# Altium schematic sheet lps_regulator_rev_11.SchDoc(lps_regulator_rev_11)
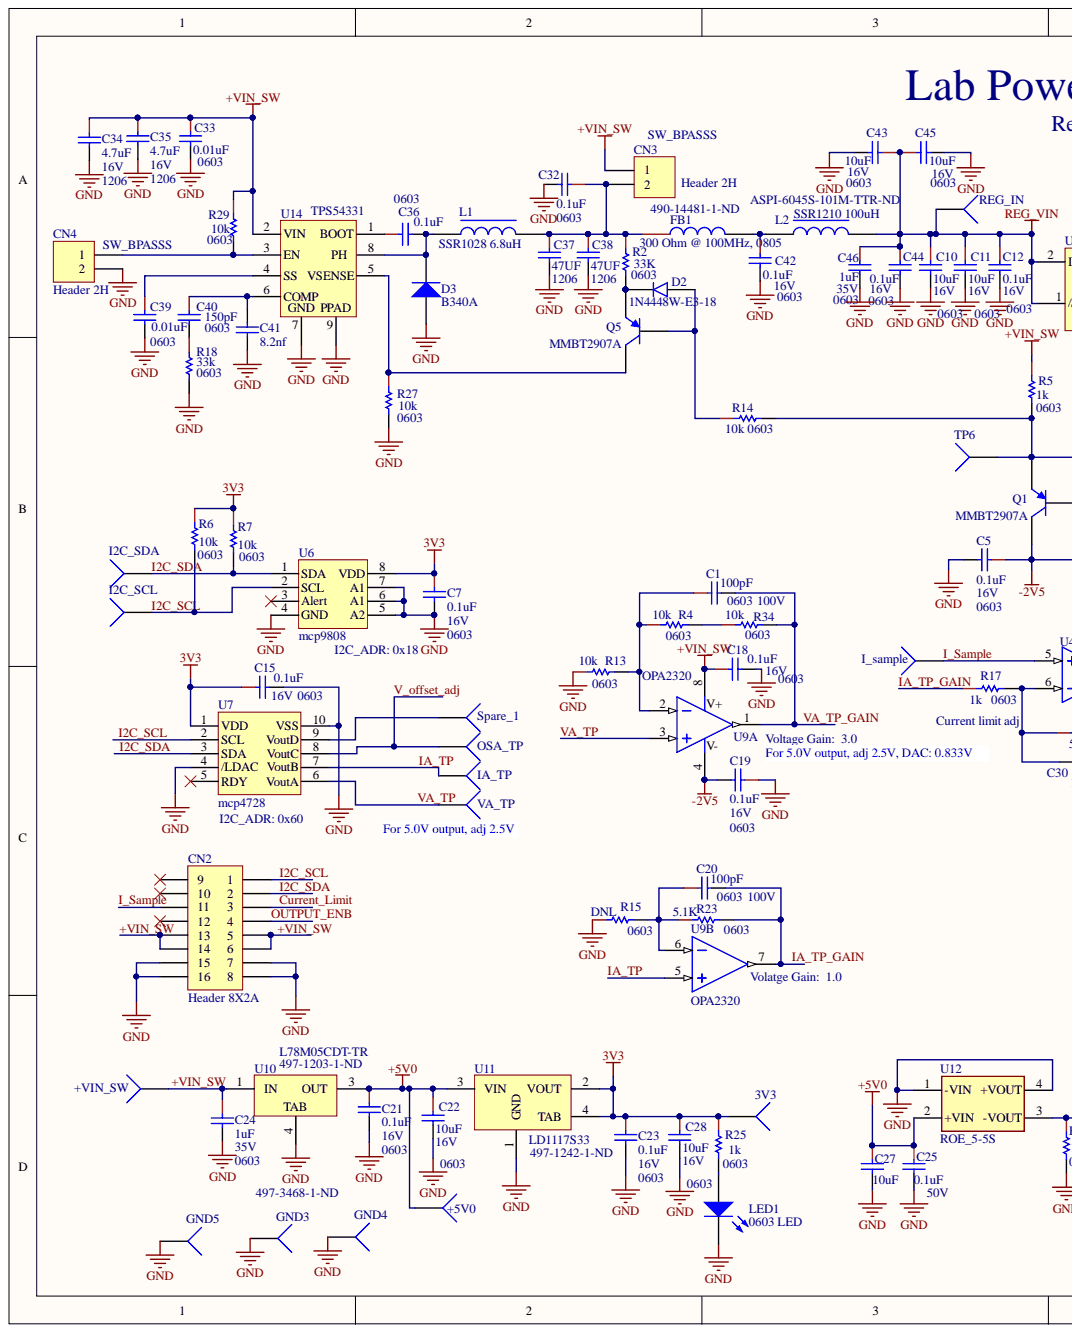
[diagram p0: lps_regulator_rev_11.SchDoc, left]
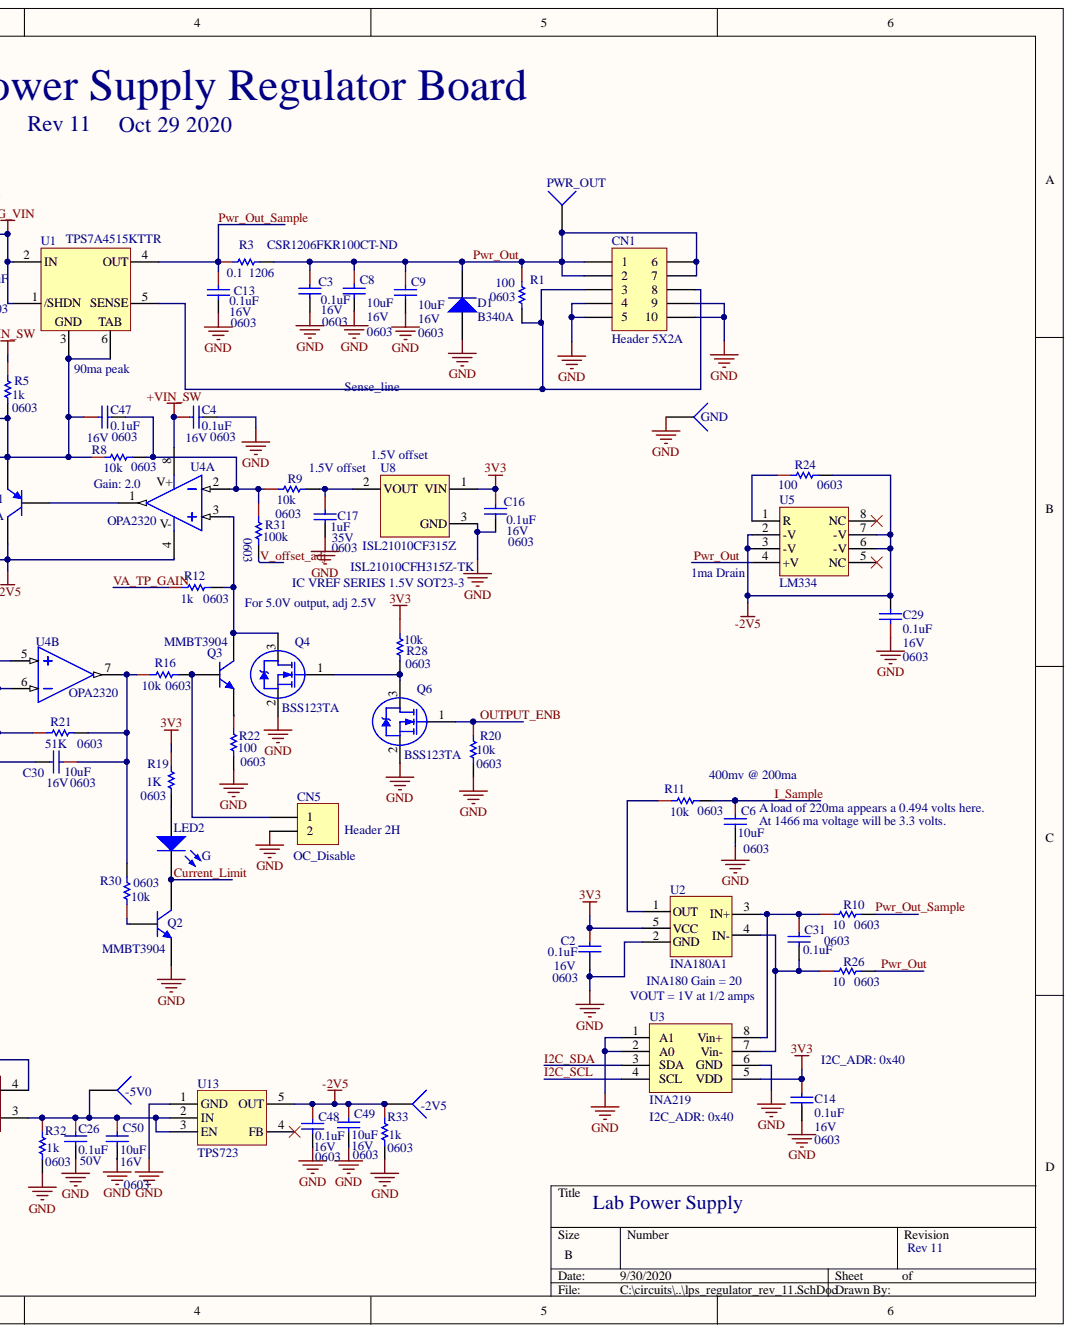
[diagram p1: lps_regulator_rev_11.SchDoc, right]

## Parts
3V3  TestPoint_BLK  [TestPoint-TH 42 mil]  (value not drawn)
+5V0  TestPoint_BLK  [TestPoint-TH 42 mil]  (value not drawn)
+VIN_SW  TestPoint_BLK  [TestPoint-TH 42 mil]  (value not drawn)
-2V5  TestPoint_BLK  [TestPoint-TH 42 mil]  (value not drawn)
-5V0  TestPoint_BLK  [TestPoint-TH 42 mil]  (value not drawn)
C1  100pF  Package (Imperial): 0603  [CAPC0603(1608)_N]
C2  0.1uF  Voltage (Rated): 16V  Package (Imperial): 0603  [CAPC0603(1608)_M]
C3  0.1uF  Voltage (Rated): 16V  Package (Imperial): 0603  [CAPC0603(1608)_N]
C4  0.1uF  Voltage (Rated): 16V  Package (Imperial): 0603  [CAPC0603(1608)_N]
C5  0.1uF  Voltage (Rated): 16V  Package (Imperial): 0603  [CAPC0603(1608)_N]
C6  10uF  Package (Imperial): 0603  [CAPC0603(1608)_N]
C7  0.1uF  Voltage (Rated): 16V  Package (Imperial): 0603  [CAPC0603(1608)_M]
C8  10uF  Voltage (Rated): 16V  Package (Imperial): 0603  [CAPC0603(1608)_N]
C9  10uF  Voltage (Rated): 16V  Package (Imperial): 0603  [CAPC0603(1608)_N]
C10  10uF  Voltage (Rated): 16V  Package (Imperial): 0603  [CAPC0603(1608)_N]
C11  10uF  Voltage (Rated): 16V  Package (Imperial): 0603  [CAPC0603(1608)_N]
C12  0.1uF  Voltage (Rated): 16V  Package (Imperial): 0603  [CAPC0603(1608)_N]
C13  0.1uF  Voltage (Rated): 16V  Package (Imperial): 0603  [CAPC0603(1608)_M]
C14  0.1uF  Voltage (Rated): 16V  Package (Imperial): 0603  [CAPC0603(1608)_N]
C15  0.1uF  Voltage (Rated): 16V  Package (Imperial): 0603  [CAPC0603(1608)_N]
C16  0.1uF  Voltage (Rated): 16V  Package (Imperial): 0603  [CAPC0603(1608)_N]
C17  1uF  Voltage (Rated): 35V  Package (Imperial): 0603  [CAPC0603(1608)_M]
C18  0.1uF  Voltage (Rated): 16V  Package (Imperial): 0603  [CAPC0603(1608)_M]
C19  0.1uF  Voltage (Rated): 16V  Package (Imperial): 0603  [CAPC0603(1608)_M]
C20  100pF  Voltage (Rated): 100V  Package (Imperial): 0603  [CAPC0603(1608)_N]
C21  0.1uF  Voltage (Rated): 16V  Package (Imperial): 0603  [CAPC0603(1608)_M]
C22  10uF  Voltage (Rated): 16V  Package (Imperial): 0603  [CAPC0603(1608)_N]
C23  0.1uF  Voltage (Rated): 16V  Package (Imperial): 0603  [CAPC0603(1608)_M]
C24  1uF  Voltage (Rated): 35V  Package (Imperial): 0603  [CAPC0603(1608)_M]
C25  0.1uF  Voltage - Rated: 50V  [CAPC0805(2012)_N]
C26  0.1uF  Voltage - Rated: 50V  [CAPC0805(2012)_N]
C27  10uF  [CAPC0805(2012)_M]
C28  10uF  Voltage (Rated): 16V  Package (Imperial): 0603  [CAPC0603(1608)_N]
C29  0.1uF  Voltage (Rated): 16V  Package (Imperial): 0603  [CAPC0603(1608)_M]
C30  10uF  Voltage (Rated): 16V  Package (Imperial): 0603  [CAPC0603(1608)_M]
C31  0.1uF  Package (Imperial): 0603  [CAPC0603(1608)_N]
C32  0.1uF  [CAPC0603(1608)_N]
C33  0.01uF  Package (Imperial): 0603  [CAPC0603(1608)_N]
C34  4.7uF  Voltage (Rated): 16V  Package (Imperial): 1206  [CAPC1206(3216)_N]
C35  4.7uF  Voltage (Rated): 16V  Package (Imperial): 1206  [CAPC1206(3216)_N]
C36  0.1uF  Package (Imperial): 0603  [CAPC0603(1608)_N]
C37  47UF  Package (Imperial): 1206  [CAPC1206(3216)_L]
C38  47UF  Package (Imperial): 1206  [CAPC1206(3216)_L]
C39  0.01uF  Package (Imperial): 0603  [CAPC0603(1608)_N]
C40  150pF  Package (Imperial): 0603  [CAPC0603(1608)_M]
C41  8.2nf  Case Code (Imperial): 0603  [CAPC1608X87X35ML15T15]
C42  0.1uF  Voltage (Rated): 16V  Package (Imperial): 0603  [CAPC0603(1608)_N]
C43  10uF  Voltage (Rated): 16V  Package (Imperial): 0603  [CAPC0603(1608)_N]
C44  0.1uF  Voltage (Rated): 16V  Package (Imperial): 0603  [CAPC0603(1608)_N]
C45  10uF  Voltage (Rated): 16V  [CAPC0603(1608)_N]
C46  1uF  Voltage (Rated): 35V  Package (Imperial): 0603  [CAPC0603(1608)_M]
C47  0.1uF  Voltage (Rated): 16V  Package (Imperial): 0603  [CAPC0603(1608)_N]
C48  0.1uF  Voltage (Rated): 16V  Package (Imperial): 0603  [CAPC0603(1608)_M]
C49  10uF  Voltage (Rated): 16V  Package (Imperial): 0603  [CAPC0603(1608)_N]
C50  10uF  Voltage (Rated): 16V  Package (Imperial): 0603  [CAPC0603(1608)_N]
CN1  Header 5X2A  [HDR2X5_CEN]
CN2  Header 8X2A  [HDR2X8_CEN]
CN3  Header 2H  [HDR1X2H]
CN4  Header 2H  [HDR1X2H]
CN5  Header 2H  [HDR1X2H]
D1  B340A  [DO-214AC]
D2  1N4448W-E3-18  [SOD123]
D3  B340A  [DO-214AC]
FB1  300 Ohm @ 100MHz, 0805  [RESC0805(2012)_N]
GND  TestPoint_BLK  [TestPoint-TH 42 mil]  (value not drawn)
GND3  TestPoint_BLK  [TestPoint-TH 42 mil]  (value not drawn)
GND4  TestPoint_BLK  [TestPoint-TH 42 mil]  (value not drawn)
GND5  TestPoint_BLK  [TestPoint-TH 42 mil]  (value not drawn)
I2C_SCL  TestPoint_BLK  [TestPoint-TH 42 mil]  (value not drawn)
I2C_SDA  TestPoint_BLK  [TestPoint-TH 42 mil]  (value not drawn)
I_sample  TestPoint_BLK  [TestPoint-TH 42 mil]  (value not drawn)
IA_TP  TestPoint_BLK  [TestPoint-TH 42 mil]  (value not drawn)
L1  SSR1028 6.8uH  [SRU1028]
L2  SSR1210 100uH  [SRR1210]
LED1  0603 LED  [SM-LED-0603]
LED2  G  [SM-LED-0603]
OSA_TP  TestPoint_BLK  [TestPoint-TH 42 mil]  (value not drawn)
PWR_OUT  TestPoint_BLK  [TestPoint-TH 42 mil]  (value not drawn)
Q1  MMBT2907A  [SOT23]
Q2  MMBT3904  [SOT23]
Q3  MMBT3904  [SOT23]
Q4  BSS123TA  [SOT23-3L]
Q5  MMBT2907A  [SOT23]
Q6  BSS123TA  [SOT23-3L]
R1  100  Package (Imperial): 0603  [RESC0603(1608)_N]
R2  Resistance: 33K  Package (Imperial): 0603  [RESC0603(1608)_M]  (hidden comment: 10K)
R3  Resistance: 0.1  Package (Metric): 1206  [RESC1206(3216)_N]  (hidden comment: 0.33)
R4  10k  Package (Imperial): 0603  [RESC0603(1608)_L]
R5  Resistance: 1k  Package (Imperial): 0603  [RESC0603(1608)_M]  (hidden comment: 10K)
R6  Package (Imperial): 0603  [RESC0603(1608)_M]  (hidden comment: 10K)
R7  Package (Imperial): 0603  [RESC0603(1608)_M]  (hidden comment: 10K)
R8  Package (Imperial): 0603  [RESC0603(1608)_M]  (hidden comment: 10K)
R9  10K  [RESC0603(1608)_M]
R10  Package (Imperial): 0603  [RESC0603(1608)_M]  (hidden comment: 10K)
R11  Package (Imperial): 0603  [RESC0603(1608)_M]  (hidden comment: 10K)
R12  Resistance: 1k  Package (Imperial): 0603  [RESC0603(1608)_M]  (hidden comment: 1.0K)
R13  10k  Package (Imperial): 0603  [RESC0603(1608)_L]
R14  Package (Imperial): 0603  [RESC0603(1608)_M]  (hidden comment: 10K)
R15  Resistance: DNL  Package (Imperial): 0603  [RESC0603(1608)_M]  (hidden comment: 1.0K)
R16  Resistance: 10k  Package (Imperial): 0603  [RESC0603(1608)_M]  (hidden comment: 1.0K)
R17  Resistance: 1k  Package (Imperial): 0603  [RESC0603(1608)_M]  (hidden comment: 1.0K)
R18  Package (Imperial): 0603  [RESC0603(1608)_N]  (hidden comment: 33K)
R19  Resistance: 1K  Package (Imperial): 0603  [RESC0603(1608)_M]  (hidden comment: 1.0K)
R20  Package (Imperial): 0603  [RESC0603(1608)_M]  (hidden comment: 10K)
R21  Resistance: 51K  Package (Imperial): 0603  [RESC0603(1608)_M]  (hidden comment: 100K)
R22  Package (Imperial): 0603  [RESC0603(1608)_M]  (hidden comment: 1.0K)
R23  100  Package (Imperial): 0603  [RESC0603(1608)_N]
R24  100  Package (Imperial): 0603  [RESC0603(1608)_N]
R25  Resistance: 1k  Package (Imperial): 0603  [RESC0603(1608)_M]  (hidden comment: 1.0K)
R26  Package (Imperial): 0603  [RESC0603(1608)_M]  (hidden comment: 10K)
R27  Package (Imperial): 0603  [RESC0603(1608)_M]  (hidden comment: 10K)
R28  Package (Imperial): 0603  [RESC0603(1608)_M]  (hidden comment: 10K)
R29  Package (Imperial): 0603  [RESC0603(1608)_M]  (hidden comment: 10K)
R30  Resistance: 10k  Package (Imperial): 0603  [RESC0603(1608)_M]  (hidden comment: 1.0K)
R31  Resistance: 100k  Package (Imperial): 0603  [RESC0603(1608)_M]  (hidden comment: 1.0K)
R32  Resistance: 1k  Package (Imperial): 0603  [RESC0603(1608)_M]  (hidden comment: 1.0K)
R33  Resistance: 1k  Package (Imperial): 0603  [RESC0603(1608)_M]  (hidden comment: 1.0K)
R34  10k  Package (Imperial): 0603  [RESC0603(1608)_L]
REG_IN  TestPoint_BLK  [TestPoint-TH 42 mil]  (value not drawn)
Spare_1  TestPoint_BLK  [TestPoint-TH 42 mil]  (value not drawn)
TP6  TestPoint_BLK  [TestPoint-TH 42 mil]  (value not drawn)
U1  TPS7A4515KTTR  [TPS7A4515KTTR]
U2  INA180A1  [SOT-23-5_MC]
U3  INA219  [INA219]
U4  OPA2320  [SOIC-8_NoPad]  (parts A,B)
U5  LM334  [LM334]
U6  mcp9808  [8MSOP]
U7  mcp4728  [MCP4728]
U8  ISL21010CF315Z  [SOT23]
U9  OPA2320  [SOIC-8_NoPad]  (parts A,B)
U10  (no value)  [TO-252_N]
U11  LD1117S33  [DSO-G3]
U12  ROE_5-5S  [ROE_5-55]
U13  TPS723  [SOt-23-5]
U14  TPS54331  [TPS54331]
VA_TP  TestPoint_BLK  [TestPoint-TH 42 mil]  (value not drawn)

## Nets
3V3: 3V3.1 C2.1 C7.1 C14.1 C15.1 C16.1 C23.1 C28.1 R6.1 R7.1 R19.1 R25.1 R28.1 U2.5(VCC) U3.5(VDD) U6.8(VDD) U7.1(VDD) U8.1 U11.2(VOUT) U11.4(TAB)
+5V0: +5V0.1 C21.1 C22.1 C25.1 C27.1 U10.3 U11.3(VIN) U12.2(+VIN)
-2V5: -2V5.1 C5.1 C19.2 C29.2 C48.1 C49.1 Q1.3 R24.2 R33.1 U4.4 U5.2(-V) U5.3(-V) U5.6(-V) U5.7(-V) U9.4 U13.5(OUT)
GND: C2.2 C3.2 C4.2 C5.2 C6.2 C7.2 C8.2 C9.2 C10.2 C11.2 C12.2 C13.2 C14.2 C15.2 C16.2 C17.2 C18.2 C19.1 C21.2 C22.2 C23.2 C24.2 C25.2 C26.2 C27.2 C28.2 C29.1 C32.2 C33.2 C34.2 C35.2 C37.2 C38.2 C39.2 C41.1 C42.2 C43.2 C44.2 C45.2 C46.2 C48.2 C49.2 C50.2 CN1.4 CN1.5 CN1.9 CN1.10 CN2.7 CN2.8 CN2.15 CN2.16 CN4.2 CN5.2 D1.1 D3.1 GND.1 GND3.1 GND4.1 GND5.1 LED1.2 Q2.2 Q4.2 Q6.2 R13.1 R15.1 R18.2 R20.2 R22.2 R27.2 R32.2 R33.2 U2.2(GND) U3.1(A1) U3.2(A0) U3.6(GND) U6.4(GND) U6.5(A2) U6.6(A1) U6.7(A1) U7.4(/LDAC) U7.10(VSS) U8.3 U10.4 U11.1(GND) U12.1(-VIN) U12.4(+VOUT) U13.1(GND) U14.7 U14.9
REG_VIN: C10.1 C11.1 C12.1 C43.1 C44.1 C45.1 C46.1 L2.2 REG_IN.1 U1.1(/SHDN) U1.2(IN)
+VIN_SW: +VIN_SW.1 C4.1 C18.1 C24.1 C33.1 C34.1 C35.1 CN2.5 CN2.6 CN2.13 CN2.14 CN3.1 R5.1 R29.1 U4.8 U9.8 U10.1 U14.2(VIN)
Current_Limit: CN2.3 LED2.2 Q2.3
I2C_SCL: CN2.1 I2C_SCL.1 R6.2 U3.4(SCL) U6.2(SCL) U7.2(SCL)
I2C_SDA: CN2.2 I2C_SDA.1 R7.2 U3.3(SDA) U6.1(SDA) U7.3(SDA)
I_Sample: C6.1 CN2.11 I_sample.1 R11.2 U4.5
IA_TP: IA_TP.1 U7.7(VoutB) U9.5
IA_TP_GAIN: C20.2 R17.1 R23.2 U9.7
OUTPUT_ENB: CN2.4 Q6.1 R20.1
Pwr_Out: C3.1 C8.1 C9.1 CN1.1 CN1.2 CN1.6 CN1.7 D1.2 PWR_OUT.1 R1.1 R3.2 R26.2 U5.4(+V)
Pwr_Out_Sample: C13.1 R3.1 R10.2 U1.4(OUT)
V_offset_adj: OSA_TP.1 R31.2 U7.8(VoutC)
VA_TP: U7.6(VoutA) U9.3 VA_TP.1
VA_TP_GAIN: C1.2 R12.1 R34.2 U9.1
Net-5V0_1: -5V0.1 C26.1 C50.1 R32.1 U12.3(-VOUT) U13.2(IN) U13.3(EN)
NetC1_1: C1.1 R4.1 R13.2 U9.2
NetC17_1: C17.1 R9.2 U8.2
NetC20_1: C20.1 R15.2 R23.1 U9.6
NetC30_1: C30.1 R16.1 R21.2 R30.2 U4.7
NetC30_2: C30.2 R17.2 R21.1 U4.6
NetC31_1: C31.1 R10.1 U2.3(IN+) U3.8(Vin+)
NetC31_2: C31.2 R26.1 U2.4(IN-) U3.7(Vin-)
NetC32_1: C32.1 C37.1 C38.1 CN3.2 FB1.1 L1.2 R2.1
NetC36_1: C36.1 U14.1(BOOT)
NetC36_2: C36.2 D3.2 L1.1 U14.8(PH)
NetC39_1: C39.1 U14.4(SS)
NetC40_1: C40.1 C41.2 U14.6(COMP)
NetC40_2: C40.2 R18.1
NetC42_1: C42.1 FB1.2 L2.1
NetC47_1: C47.1 Q1.2 R5.2 R8.1 R14.2 TP6.1 U1.3 U1.6
NetC47_2: C47.2 R8.2 R9.1 R31.1 U4.2
NetCN1_3: CN1.3 CN1.8 R1.2 U1.5(SENSE)
NetCN4_1: CN4.1 R29.2 U14.3(EN)
NetCN5_1: CN5.1 Q3.1 R16.2
NetD2_1: D2.1 Q5.1 R14.1
NetD2_2: D2.2 Q5.2 R2.2
NetLED1_1: LED1.1 R25.2
NetLED2_1: LED2.1 R19.2
NetQ1_1: Q1.1 U4.1
NetQ2_1: Q2.1 R30.1
NetQ3_2: Q3.2 R22.1
NetQ3_3: Q3.3 Q4.3 R12.2 U4.3
NetQ4_1: Q4.1 Q6.3 R28.2
NetQ5_3: Q5.3 R27.1 U14.5(VSENSE)
NetR4_2: R4.2 R34.1
NetR11_1: R11.1 U2.1(OUT)
NetR24_1: R24.1 U5.1(R)
NetSpare_1_1: Spare_1.1 U7.9(VoutD)

Not connected: CN2 9 10 12; U5 5(NC) 8(NC); U6 3(Alert); U7 5(RDY); U13 4(FB)

## Library data of the parts
3V3 +5V0 +VIN_SW -2V5 -5V0 GND GND3-GND5 I2C_SCL I2C_SDA I_sample IA_TP OSA_TP PWR_OUT REG_IN Spare_1 TP6 VA_TP = CMP-005-0210-01: Test Point - Black; Manufacturer Part Number: 5001; Manufacturer: Keystone Electronics; Type: PC Test Point, Miniature; Terminal: 0.010" x 0.020" (0.25mm x 0.51mm) Phosphor Bronze Wire, Silver Plated; Stock 1: 335679; RoHS: RoHS Compliant; Pricing 1: 1=0.37, 10=0.316, 50=0.2298, 100=0.2209, 250=0.19832, 500=0.18932, 1000=0.1616, 2500=0.14424, 5000=0.13523 (USD); Pin Count: 1; Packaging: Bulk; Mounting Type: 0.040" (1.02mm) Hole Diameter; Material: Base, Nylon 46, UL 94V-0; Designator: TestPoint_BLK; Component Name: TestPoint_BLK; ComponentLink1URL: http://www.keyelco.com/product-pdf.cfm?p=1310; ComponentLink1Description: http://www.keyelco.com/product-pdf.cfm?p=1310; Component Kind: Standard; Color: Black; Library Name: OLogic Nexus Vault
C1 = CMP-007-0074-08: CAP CER 100PF 50V 5% NP0 0603; Voltage (Rated): 100V; Temperature Coefficient: C0G, NP0; Package (Metric): 1608; Dielectric Material: Ceramic; Tolerance: 5%; Mounting Type: SMD; Component Kind: Standard; Capacitance: 100pF; Library Name: OLogic Nexus Vault
C2-C5 C7 C12-C16 C18 C19 C21 C23 C29-C31 C36 C42 C44 C47 C48 = CMP-007-0042-08: CAP CER 0.1UF 16V 10% X7R 0603; Package (Metric): 1608; Dielectric Material: Ceramic; Capacitance: 100nF; Tolerance: 10%; Temperature Coefficient: X7R; Mounting Type: SMD; Component Kind: Standard; Library Name: OLogic Nexus Vault
  C31 C36: Voltage (Rated): 16V
C6 C8-C11 C22 C28 C43 C49 C50 = CMP-001-0603-12: CAP CER 10UF 16V X5R 0603; Tolerance: 10%; Temperature (Min): -55°C; Temperature (Max): 85°C; Package (Metric): 1005; Dielectric Material: Ceramic; Capacitance: 10µF; Width: 800µm; Temperature Coefficient: X5R; Mounting Type: SMD; Height: 800µm; Component Kind: Standard; Library Name: OLogic Nexus Vault
  C6: Voltage (Rated): 16V
C17 C24 C40 C46 = CMP-007-0109-08: CAP CER 1UF 35V 10% X5R 0603; Temperature (Min): -55°C; Temperature (Max): 85°C; Package (Metric): 1608; Dielectric Material: Ceramic; Capacitance: 1µF; Width: 800µm; Tolerance: 10%; Temperature Coefficient: X5R; Mounting Type: SMD; Length: 1.6mm; Height: 900µm; Component Kind: Standard; Library Name: OLogic Nexus Vault
  C40: Voltage (Rated): 35V
C20 = CMP-007-0074-08: CAP CER 100PF 50V 5% NP0 0603; Temperature Coefficient: C0G, NP0; Package (Metric): 1608; Dielectric Material: Ceramic; Tolerance: 5%; Mounting Type: SMD; Component Kind: Standard; Capacitance: 100pF; Library Name: OLogic Nexus Vault
C25 C26 = CAP CER 0.1UF 50V 10% X7R 0805: 0.1uF 0805 50V; Manufacturer Part Number: C0805C104K5RACTU; Manufacturer: Kemet; Tolerance: ±10%; Temperature Coefficient: X7R; Capacitance: 0.1µF; Thickness (Max): 0.035" (0.88mm); Supplier Part Number 1: 399-1170-1-ND; Supplier 1: Digi-Key; Stock 1: 2639857; Size / Dimension: 0.079" L x 0.049" W (2.00mm x 1.25mm); RoHS: RoHS Compliant; Ratings: -; Pricing 1: 1=0.1, 10=0.049, 25=0.0348, 50=0.0266, 100=0.0224, 250=0.01836, 1000=0.012 (USD); Packaging: Cut Tape (CT); Package / Case: 0805 (2012 Metric); Operating Temperature: -55°C ~ 125°C; Mounting Type: Surface Mount, MLCC; Library Name: OLogic-Passive.IntLib; Lead Style: -; Lead Spacing: -; Height - Seated (Max): -; Features: -; ComponentLink1URL: http://www.kemet.com/datasheets&C0805C104K5RACTU; ComponentLink1Description: http://www.kemet.com/datasheets&C0805C104K5RACTU; Component Kind: Standard; Category: Capacitors; Applications: General Purpose
C27 = CAP CER 10UF 16V 10% X5R 0805: 10UF 0805 16V; Manufacturer Part Number: GRM21BR61C106KE15L; Manufacturer: Murata Electronics North America; Tolerance: ±10%; Temperature Coefficient: X5R; Capacitance: 10µF; Voltage - Rated: 16V; Thickness (Max): 0.053" (1.35mm); Supplier Part Number 1: 490-3886-1-ND; Supplier 1: Digi-Key; Stock 1: 1725870; Size / Dimension: 0.079" L x 0.049" W (2.00mm x 1.25mm); RoHS: RoHS Compliant; Ratings: -; Pricing 1: 1=0.25, 10=0.171, 50=0.1038, 100=0.0872, 250=0.07056, 500=0.06226, 1000=0.05291 (USD); Packaging: Cut Tape (CT); Package / Case: 0805 (2012 Metric); Operating Temperature: -55°C ~ 85°C; Mounting Type: Surface Mount, MLCC; Library Name: OLogic-Passive.IntLib; Lead Style: -; Lead Spacing: -; Height - Seated (Max): -; Features: -; ComponentLink1URL: http://www.murata.com/products/catalog/pdf/c02e.pdf; ComponentLink1Description: http://www.murata.com/products/catalog/pdf/c02e.pdf; Component Kind: Standard; Category: Capacitors; Applications: General Purpose
C32 = CMP-007-0042-08: CAP CER 0.1UF 16V 10% X7R 0603; Package (Metric): 1608; Package (Imperial): 0603; Dielectric Material: Ceramic; Capacitance: 100nF; Voltage (Rated): 16V; Tolerance: 10%; Temperature Coefficient: X7R; Mounting Type: SMD; Component Kind: Standard; Library Name: OLogic Nexus Vault
C33 = CMP-007-0028-08: CAP CER 0.01uF 50V 10% X7R 0603; Temperature (Min): -55°C; Temperature (Max): 125°C; Package (Metric): 1608; Dielectric Material: Ceramic; Capacitance: 10nF; Width: 800µm; Voltage (Rated): 50V; Tolerance: 10%; Temperature Coefficient: X7R; Mounting Type: SMD; Length: 1.6mm; Height: 900µm; Component Kind: Standard; Library Name: OLogic Nexus Vault
C34 C35 = CMP-007-0147-08: CAP CER 4.7UF 50V 10% X7R 1206; Package (Metric): 3216; Dielectric Material: Ceramic; Capacitance: 4.7µF; Tolerance: 10%; Temperature Coefficient: X7R; Mounting Type: SMD; Component Kind: Standard; Library Name: OLogic Nexus Vault
C37 C38 = CMP-001-0623-11: CAP CER 47UF 25V 1206; Voltage (Rated): 100V; Tolerance: 20%; Temperature Coefficient: X5R; Temperature (Min): -55°C; Temperature (Max): 85°C; Package (Metric): 3216; Dielectric Material: Ceramic; Capacitance: 47µF; Mounting Type: SMD; Length: 3.2mm; Height: 1.6mm; Component Kind: Standard; Library Name: OLogic Nexus Vault
C39 = CMP-007-0128-08: CAP CER 22PF 50V 5% NP0 0603; Temperature Coefficient: C0G, NP0; Package (Metric): 1608; Dielectric Material: Ceramic; Voltage (Rated): 50V; Tolerance: 5%; Mounting Type: SMD; Component Kind: Standard; Capacitance: 22pF; Library Name: OLogic Nexus Vault
C41 = CMP-001-0751-01: CAP CER 820PF 50V X7R 0603; Tolerance: 10%; Dissipation Factor: 2.5%; Dielectric Material: Ceramic; Width: 0.8mm; Voltage Rating (DC): 50V; Voltage Rating: 50V; Voltage: 50V; Thickness: 0.034inch; Termination: SMD/SMT; REACH SVHC: No SVHC; Radiation Hardening: No; Packaging: Tape and Reel; Package Quantity: 1; Mount: PCB, Surface Mount; Min Operating Temperature: -55°C; Max Operating Temperature: 125°C; Length: 1.6mm; Lead Pitch: 0.7mm; Height: 0.8mm; Dielectric: X7R; Depth: 0.8mm; Contact Plating: Matte, Tin; Component Kind: Standard; Case Code (Metric): 1608; Case/Package: 0603; Capacitance: 820pF; Library Name: OLogic Nexus Vault
C45 = CMP-001-0603-12: CAP CER 10UF 16V X5R 0603; Tolerance: 10%; Temperature (Min): -55°C; Temperature (Max): 85°C; Package (Metric): 1005; Package (Imperial): 0603; Dielectric Material: Ceramic; Capacitance: 10µF; Width: 800µm; Temperature Coefficient: X5R; Mounting Type: SMD; Height: 800µm; Component Kind: Standard; Library Name: OLogic Nexus Vault
CN1 = CMP-001-0310-01: Header, 5-Pin, Dual row; Signal Integrity: Connector; Pin Count: 10; LatestRevisionNote: Re-released for DXP Platform.; LatestRevisionDate: 17-Jul-2002; Designator: Header 5X2A; Component Name: Header 5X2A; Component Kind: Standard; Publisher: Altium Limited; Library Name: OLogic Nexus Vault
CN2 = CMP-001-0325-01: Header, 8-Pin, Dual row; Signal Integrity: Connector; Pin Count: 16; LatestRevisionNote: Re-released for DXP Platform.; LatestRevisionDate: 17-Jul-2002; Designator: Header 8X2A; Component Name: Header 8X2A; Component Kind: Standard; Publisher: Altium Limited; Library Name: OLogic Nexus Vault
CN3-CN5 = CMP-001-0287-01: Header, 2-Pin, Right Angle; Manufacturer Part Number: M20-9960245; Manufacturer: Harwin Inc.; Style: Board to Board or Cable; Shrouding: Unshrouded; Pitch - Mating: 0.100" (2.54mm); Number of Positions Loaded: All; Number of Positions: 2; Material Flammability Rating: UL94 V-0; Mated Stacking Heights: 11.72mm, 12.62mm, 12.72mm, 13.62mm, 18.22mm, 19.12mm; Current Rating: 3A per Contact; Contact Length - Post: 0.118" (3.00mm); Contact Length - Mating: 0.240" (6.10mm); Connector Type: Header, Cuttable; Voltage Rating: -; Termination: Solder; Stock 1: 17504; Signal Integrity: Connector; Row Spacing - Mating: -; RoHS: RoHS Compliant; Pricing 1: 1=0.15, 10=0.141, 100=0.087, 500=0.072, 1000=0.063, 5000=0.054, 10000=0.0525 (USD); Pin Count: 2; Part Status: Active; Packaging: Bulk; Overall Contact Length: -; Operating Temperature: -40°C ~ 105°C; Number of Rows: 1; Mounting Type: Through Hole, Right Angle; LatestRevisionNote: Re-released for DXP Platform.; LatestRevisionDate: 17-Jul-2002; Insulation Material: Polyamide (PA6T), Nylon 6T; Insulation Height: 0.100" (2.54mm); Insulation Color: Black; Ingress Protection: -; Features: -; Fastening Type: -; Designator: Header 2H; Contact Type: Male Pin; Contact Shape: Square; Contact Material: Brass; Contact Finish Thickness - Post: -; Contact Finish Thickness - Mating: -; Contact Finish - Post: -; Contact Finish - Mating: Gold; Component Name: Header 2H; ComponentLink1URL: https://cdn.harwin.com/pdfs/M20-996.pdf; ComponentLink1Description: https://cdn.harwin.com/pdfs/M20-996.pdf; Component Kind: Standard; Applications: -; Publisher: Altium Limited; Library Name: OLogic Nexus Vault
D1 D3 = CMP-001-0130-02: DIODE SCHOTTKY 60V 3A; Manufacturer Part Number: SK36A-LTP; Manufacturer: Micro Commercial Co; Voltage - Forward (Vf) (Max) @ If: 750mV @ 3A; Speed: Fast Recovery =< 500ns, > 200mA (Io); Current - Reverse Leakage @ Vr: 500µA @ 60V; Capacitance @ Vr, F: 250pF @ 4V, 1MHz; Supplier Device Package: DO-214AC (SMA); Stock 1: 26020; RoHS: RoHS Compliant; Pricing 1: 1=0.6, 10=0.42, 25=0.3448, 100=0.276, 250=0.20072, 500=0.1631, 1000=0.12545, 2500=0.11291 (USD); Pin Count: 2; Part Status: Active; Packaging: Cut Tape (CT); Package / Case: DO-214AC, SMA; Operating Temperature - Junction: -50°C ~ 125°C; Mounting Type: Surface Mount; Diode Type: Schottky; Designator: SK36A-LTP; Current - Average Rectified (Io): 3A; Component Name: SK36A-LTP; ComponentLink1URL: http://www.mccsemi.com/up_pdf/SK32A-LT~SK310A-LT(DO-214AC).PDF; ComponentLink1Description: http://www.mccsemi.com/up_pdf/SK32A-LT~SK310A-LT(DO-214AC).PDF; Component Kind: Standard; Library Name: OLogic Nexus Vault
D2 = CMP-001-0983-02: Diodes - General Purpose, Power, Switching 100 Volt 500mA 4ns; Max Reverse Voltage (DC): 75V; Max Reverse Leakage Current: 5uA; Max Repetitive Reverse Voltage (Vrrm): 100V; Max Power Dissipation: 500mW; Forward Current: 150mA; Element Configuration: Single; Weight: 0.000363oz; Reverse Recovery Time: 4ns; Recovery Time: 4ns; Peak Non-Repetitive Surge Current: 500mA; Packaging: Tape and Reel; Package Quantity: 10000; Mount: Surface Mount; Min Operating Temperature: -55°C; Max Surge Current: 500mA; Max Operating Temperature: 150°C; Contact Plating: Tin; Component Kind: Standard; Average Rectified Current: 150mA; Library Name: OLogic Nexus Vault
FB1 = CMP-007-0197-02: 600 Ohms @ 100MHz 1 Signal Line Ferrite Bead 0805 (2012 Metric) 2A 150mOhm; Manufacturer Part Number: 742792040; Manufacturer: Wurth Electronics Inc; Filter Type: Differential Mode - Single; DC Resistance (DCR): 150 mOhm Max; Component Name: FERRITE 600 OHM 2.0A 0805; Stock 1: 34670; Size / Dimension: 0.079" L x 0.047" W (2.00mm x 1.20mm); RoHS: RoHS Compliant; Pricing 1: 1=0.24, 50=0.2344, 100=0.2156, 500=0.20624, 1000=0.19687, 2000=0.1875 (USD); Pin Count: 2; Packaging: Cut Tape (CT); Package: 0805 (2012 Metric); Mounting Type: Surface Mount; Impedance @ Frequency: 600 Ohms @ 100MHz; Height (Max): 0.043" (1.10mm); Designator: FERRITE 600 OHM 2.0A 0805; Current Rating: 2A; ComponentLink1URL: http://katalog.we-online.de/pbs/datasheet/742792040.pdf; ComponentLink1Description: http://katalog.we-online.de/pbs/datasheet/742792040.pdf; Component Kind: Standard; Library Name: OLogic Nexus Vault; Creator: osman
L1 = CMP-001-0686-01: INDUCTOR 6.8uH  SMD; Manufacturer Part Number 1: NRS8040T4R7NJGJV; Manufacturer 1: Taiyo Yuden; Tolerance: 30%; Test Frequency: 100kHz; Shielding: Shielded; Self Resonant Frequency: 30MHz; Saturation Current: 5.4A; RMS Current (Irms): 4.1A; Inductance: 4.7uh; DC Resistance (DCR): 18mR; Core Material: Ferrite; Width: 0.315inch; Termination: SMD/SMT; REACH SVHC: Unknown; Radiation Hardening: No; Packaging: Tape and Reel; Package Quantity: 1000; Number of Pins: 2; Mount: Surface Mount; Min Operating Temperature: -25°C; Max Operating Temperature: 125°C; Max DC Current: 5.4A; Length: 8mm; Lead Pitch: 5.6mm; Lead Free: Lead Free; Inductance Tolerance: 30%; Height: 4.2mm; Halogen Free: Halogen Free; Depth: 8.2mm; Current Rating: 4.1A; Component Kind: Standard; Case/Package: 8040; Library Name: OLogic Nexus Vault
L2 = CMP-001-0686-01: INDUCTOR 100uH 2.2A SMD; Manufacturer Part Number 1: NRS8040T4R7NJGJV; Manufacturer 1: Taiyo Yuden; Tolerance: 30%; Test Frequency: 100kHz; Shielding: Shielded; Self Resonant Frequency: 30MHz; Saturation Current: 5.4A; RMS Current (Irms): 4.1A; Inductance: 4.7uh; DC Resistance (DCR): 18mR; Core Material: Ferrite; Width: 0.315inch; Termination: SMD/SMT; REACH SVHC: Unknown; Radiation Hardening: No; Packaging: Tape and Reel; Package Quantity: 1000; Number of Pins: 2; Mount: Surface Mount; Min Operating Temperature: -25°C; Max Operating Temperature: 125°C; Max DC Current: 5.4A; Length: 8mm; Lead Pitch: 5.6mm; Lead Free: Lead Free; Inductance Tolerance: 30%; Height: 4.2mm; Halogen Free: Halogen Free; Depth: 8.2mm; Current Rating: 4.1A; Component Kind: Standard; Case/Package: 8040; Library Name: OLogic Nexus Vault
LED1 = CMP-001-0009-04: 0603 SMD LED; Pin Count: 2; Designator: 0603 LED; Component Kind: Standard; Library Name: OLogic Nexus Vault
LED2 = 0603 LED: 0603 SMD LED; Vendor: Digikey; PN: P11500CT-ND; Library Name: OLogic-Diode-Opto-LED.IntLib; Component Kind: Standard
Q1 Q5 = CMP-012-0075-02: PNP General Purpose Amplifier; Manufacturer: Fairchild Semiconductor; Voltage - Collector Emitter Breakdown (Max): 60V; Vendor: Digikey; Vce Saturation (Max) @ Ib, Ic: 1.6V @ 50mA, 500mA; Power - Max: 350mW; PN: MMBT2907AFSCT-ND; Frequency - Transition: 200MHz; DC Current Gain (hFE) (Min) @ Ic, Vce: 100 @ 150mA, 10V; Current - Collector (Ic) (Max): 800mA; Transistor Type: PNP; Supplier Device Package: SOT-23; Stock 1: 74892; RoHS: RoHS Compliant; Pricing 1: 1=0.2, 10=0.187, 25=0.17, 100=0.1224, 250=0.07208, 500=0.0598, 1000=0.04079 (USD); Pin Count: 3; Packaging: Cut Tape (CT); Package / Case: TO-236-3, SC-59, SOT-23-3; MPN: MMBT2907A; Mounting Type: Surface Mount; Manufacturer Part Number: MMBT2907A; Designator: MMBT2907A; Current - Collector Cutoff (Max): -; Component Name: MMBT2907A; ComponentLink1URL: http://www.fairchildsemi.com/ds/MM/MMBT2907A.pdf; ComponentLink1Description: http://www.fairchildsemi.com/ds/MM/MMBT2907A.pdf; Component Kind: Standard; Library Name: OLogic Nexus Vault
Q2 Q3 = MMBT3904: NPN General Purpose Amplifier; MPN: MMBT3904WT1G; Manufacturer: ON Semiconductor; Voltage - Collector Emitter Breakdown (Max): 40V; Vendor: Digikey; Vce Saturation (Max) @ Ib, Ic: 300mV @ 5mA, 50mA; Power - Max: 150mW; PN: MMBT3904WT1GOSCT-ND; Frequency - Transition: 300MHz; DC Current Gain (hFE) (Min) @ Ic, Vce: 100 @ 10mA, 1V; Current - Collector (Ic) (Max): 200mA; Transistor Type: NPN; Supplier Part Number 1: MMBT3904WT1GOSCT-ND; Supplier Device Package: SC-70-3 (SOT323); Supplier 1: Digi-Key; Stock 1: 3; RoHS: RoHS Compliant; Pricing 1: 1=0.17, 10=0.154, 25=0.1404, 100=0.101, 250=0.05952, 500=0.04938, 1000=0.03368 (USD); Packaging: Cut Tape (CT); Package / Case: SC-70, SOT-323; Mounting Type: Surface Mount; Manufacturer Part Number: MMBT3904WT1G; Library Name: OLogic-Trans-Drivers.IntLib; Current - Collector Cutoff (Max): -; ComponentLink1URL: http://www.onsemi.com/pub_link/Collateral/MMBT3904WT1-D.PDF; ComponentLink1Description: http://www.onsemi.com/pub_link/Collateral/MMBT3904WT1-D.PDF; Component Kind: Standard; Category: Discrete Semiconductor Products
Q4 Q6 = CMP-012-0021-01: MOSFET N-CH 100V 170MA SOT23-3; Manufacturer: Diodes Incorporated; Vgs(th) (Max) @ Id: 2.8V @ 1mA; Vgs (Max): ±20V; Rds On (Max) @ Id, Vgs: 6 Ohm @ 100mA, 10V; Power Dissipation (Max): 360mW (Ta); FET Type: N-Channel; Drive Voltage (Max Rds On,  Min Rds On): 4.5V, 10V; Current - Continuous Drain (Id) @ 25°C: 170mA (Ta); Technology: MOSFET (Metal Oxide); Supplier Device Package: SOT-23-3; Stock 1: 35338; RoHS: RoHS Compliant; Pricing 1: 1=0.59, 10=0.418, 100=0.2742, 500=0.16202, 1000=0.12463 (USD); Pin Count: 3; Part Status: Active; Packaging: Cut Tape (CT); Package / Case: TO-236-3, SC-59, SOT-23-3; Operating Temperature: -55°C ~ 150°C (TJ); Mounting Type: Surface Mount; Manufacturer Part Number: BSS123TA; FET Feature: -; Designator: BSS123TA; Component Name: BSS123TA; ComponentLink1URL: https://www.diodes.com/assets/Datasheets/BSS123.pdf; ComponentLink1Description: https://www.diodes.com/assets/Datasheets/BSS123.pdf; Component Kind: Standard; Library Name: OLogic Nexus Vault
R1 R24 = CMP-007-0349-12: RES 100 OHM 1% 1/10W 0603 2017; Temperature Coefficient: ±100ppm/°C; Power: 100mW; Package (Metric): 1608; Tolerance: 1%; Termination: SMD/SMT; Resistance: 100; Operating Temperature: -55°C ~ 155°C; Height: 550µm; Component Kind: Standard; Library Name: OLogic Nexus Vault
R2 R5-R8 R11 R14 R20 R27-R29 = CMP-007-0359-12: RES 10K OHM 1/10W 1% 0603 SMD; Temperature Coefficient: ±100ppm/°C; Power: 100mW; Package (Metric): 1608; Tolerance: 1%; Termination: SMD/SMT; Height: 550µm; Component Kind: Standard; Library Name: OLogic Nexus Vault
  R6-R8 R11 R14 R20 R27-R29: Resistance: 10k
R3 = CMP-007-0323-14: RES 0.33 OHM 1% 1/4W 1206; Temperature Coefficient: 200ppm/°C; Power Rating: 250mW; Width: 1.6mm; Tolerance: 1%; Termination: SMD/SMT; Simulation: RESISTOR; Radiation Hardening: No; Power: 250mW; Packaging: Tape and Reel; Package Quantity: 1; Package (Imperial): 1206; Number of Terminations: 2; Number of Pins: 2; Mount: Surface Mount; Min Operating Temperature: -55°C; Military Standard: Not; Max Power Dissipation: 250mW; Max Operating Temperature: 125°C; Length: 3.2mm; Height: 600µm; Features: Automotive AEC-Q200; Depth: 1.6mm; Contact Plating: Tin; Composition: Thick Film; Component Kind: Standard; Library Name: OLogic Nexus Vault
R4 R13 R34 = CMP-007-0357-12: RES 10K OHM 0.1% 1/10W 0603; Temperature Coefficient: ±25ppm/°C; Power: 100mW; Package (Metric): 1608; Tolerance: 0.1%; Termination: SMD/SMT; Simulation: RESISTOR; Resistance: 10k; Operating Temperature: -55°C ~ 155°C; Height: 550µm; Component Kind: Standard; Library Name: OLogic Nexus Vault
R9 = CMP-007-0359-12: RES 10K OHM 1/10W 1% 0603 SMD; Temperature Coefficient: ±100ppm/°C; Power: 100mW; Package (Metric): 1608; Package (Imperial): 0603; Tolerance: 1%; Termination: SMD/SMT; Resistance: 10k; Height: 550µm; Component Kind: Standard; Library Name: OLogic Nexus Vault
R10 R26 = CMP-007-0359-12: RES 10K OHM 1/10W 1% 0603 SMD; Temperature Coefficient: ±100ppm/°C; Resistance: 10; Power: 100mW; Package (Metric): 1608; Tolerance: 1%; Termination: SMD/SMT; Height: 550µm; Component Kind: Standard; Library Name: OLogic Nexus Vault
R12 R15-R17 R19 R25 R30-R33 = CMP-007-0404-12: RES 1K OHM 1% 1/10W 0603; Temperature Coefficient: ±100ppm/°C; Power: 100mW; Package (Metric): 2012; Tolerance: 1%; Termination: SMD/SMT; Operating Temperature: -55°C ~ 155°C; Height: 550µm; Component Kind: Standard; Library Name: OLogic Nexus Vault
R18 = CMP-007-0480-12: RES 33K OHM 1/10W 5% 0603 SMD; Temperature Coefficient: ±200ppm/°C; Power: 100mW; Package (Metric): 1608; Tolerance: 5%; Termination: SMD/SMT; Simulation: RESISTOR; Resistance: 33k; Height: 550µm; Component Kind: Standard; Library Name: OLogic Nexus Vault
R21 = CMP-007-0353-12: RES 100K OHM 1% 1/10W 0603; Temperature Coefficient: ±100ppm/°C; Power: 100mW; Package (Metric): 1608; Tolerance: 1%; Termination: SMD/SMT; Operating Temperature: -55°C ~ 155°C; Height: 550µm; Component Kind: Standard; Library Name: OLogic Nexus Vault
R22 = CMP-007-0404-12: RES 1K OHM 1% 1/10W 0603; Temperature Coefficient: ±100ppm/°C; Resistance: 100; Power: 100mW; Package (Metric): 2012; Tolerance: 1%; Termination: SMD/SMT; Operating Temperature: -55°C ~ 155°C; Height: 550µm; Component Kind: Standard; Library Name: OLogic Nexus Vault
R23 = CMP-007-0349-12: RES 100 OHM 1% 1/10W 0603 2017; Temperature Coefficient: ±100ppm/°C; Resistance: 5.1K; Power: 100mW; Package (Metric): 1608; Tolerance: 1%; Termination: SMD/SMT; Operating Temperature: -55°C ~ 155°C; Height: 550µm; Component Kind: Standard; Library Name: OLogic Nexus Vault
U1 = TPS7A4515KTTR: TPS7A4515KTTR, linear regulator; Component Kind: Standard; Library Name: hdmi_lvds.IntLib
U3 = INA219: Current Monitor IC; Component Kind: Standard; Library Name: hdmi_lvds.IntLib
U4 U9 = CMP-006-0027-01: Dual Single Supply Rail-to-Rail OpAmp; Manufacturer Part Number: OPA2320AIDR; Manufacturer: Texas Instruments; Voltage - Supply, Single/Dual (±): 1.8 V ~ 5.5 V, ±0.9 V ~ 2.75 V; Slew Rate: 10 V/µs; Current - Supply: 1.45mA; Amplifier Type: General Purpose; Supplier Device Package: 8-SOIC; Stock 1: 3660; Source: OLogic-OpAmps.SCHLIB; RoHS: RoHS Compliant; Pricing 1: 1=3, 10=2.697, 100=2.2094, 500=1.88078, 1000=1.5862 (USD); Pin Count: 8; Part Status: Active; Packaging: Cut Tape (CT); Package / Case: 8-SOIC (0.154", 3.90mm Width); Output Type: Rail-to-Rail; Operating Temperature: -40°C ~ 125°C; Mounting Type: Surface Mount; Designator: OPA2320; Component Name: OPA2320; ComponentLink1URL: http://www.ti.com/lit/ds/symlink/opa2320.pdf; ComponentLink1Description: http://www.ti.com/lit/ds/symlink/opa2320.pdf; Component Kind: Standard; Base Part Number: OPA2320; Library Name: OLogic Nexus Vault
U5 = LM334: LM334, Current source; Component Kind: Standard; Library Name: hdmi_lvds.IntLib
U10 = CMP-001-0685-01: STMICROELECTRONICS         LD29150DT33R            Fixed LDO Voltage Regulator, 4.3V to 30V, 400mV Dropout, 3.3Vout, 1.5Aout, TO-252-3; Reference Voltage: 1.2423V; Quiescent Current: 15mA; Polarity: Positive; Output Voltage Accuracy: 1%; Output Voltage: 3.3V; Output Current: 1.5A; Number of Regulators: 1; Max Output Voltage: 3.333V; Width: 6.2mm; Weight: 0.01lb; Termination: SMD/SMT; REACH SVHC: No SVHC; Radiation Hardening: No; Packaging: Tape and Reel; Package Quantity: 1; Output Type: Fixed; Number of Pins: 3; Number of Outputs: 1; Nominal Output Voltage: 3.3V; Mount: Surface Mount; Min Supply Voltage: 4.3V; Min Output Voltage: 3.3V; Min Operating Temperature: -40°C; Min Input Voltage: 4.3V; Max Supply Voltage: 30V; Max Output Current: 1.5A; Max Operating Temperature: 125°C; Max Input Voltage: 30V; Length: 6.6mm; Lead Free: Lead Free; Input Bias Current: 15mA; Height: 2.4mm; Dropout Voltage: 400mV; Contact Plating: Matte, Tin; Component Kind: Standard; Case/Package: TO-252; Accuracy: 1%; Library Name: OLogic Nexus Vault
U11 = CMP-008-0010-01: 3.3 volt fixed regulator, 800mA; Pin Count: 4; Designator: LD1117S33; Component Name: LD1117S33; Component Kind: Standard; Library Name: OLogic Nexus Vault
U12 = ROE_5-5S: isolated DC/DC  converter; Component Kind: Standard
U2 = INA180A1: Component Kind: Standard; Library Name: hdmi_lvds.IntLib
U6 = mcp9808: Component Kind: Standard; Library Name: hdmi_lvds.IntLib
U7 = mcp4728: Description: MCP4728; Component Kind: Standard; Library Name: hdmi_lvds.IntLib
U8 = ISL21010CF315Z: Component Kind: Standard; Library Name: hdmi_lvds.IntLib
U13 = TPS723: Component Kind: Standard
U14 = TPS54331: Description: TPS54331; Component Kind: Standard; Library Name: hdmi_lvds.IntLib
